# Altium schematic sheet Power.SchDoc(Power)
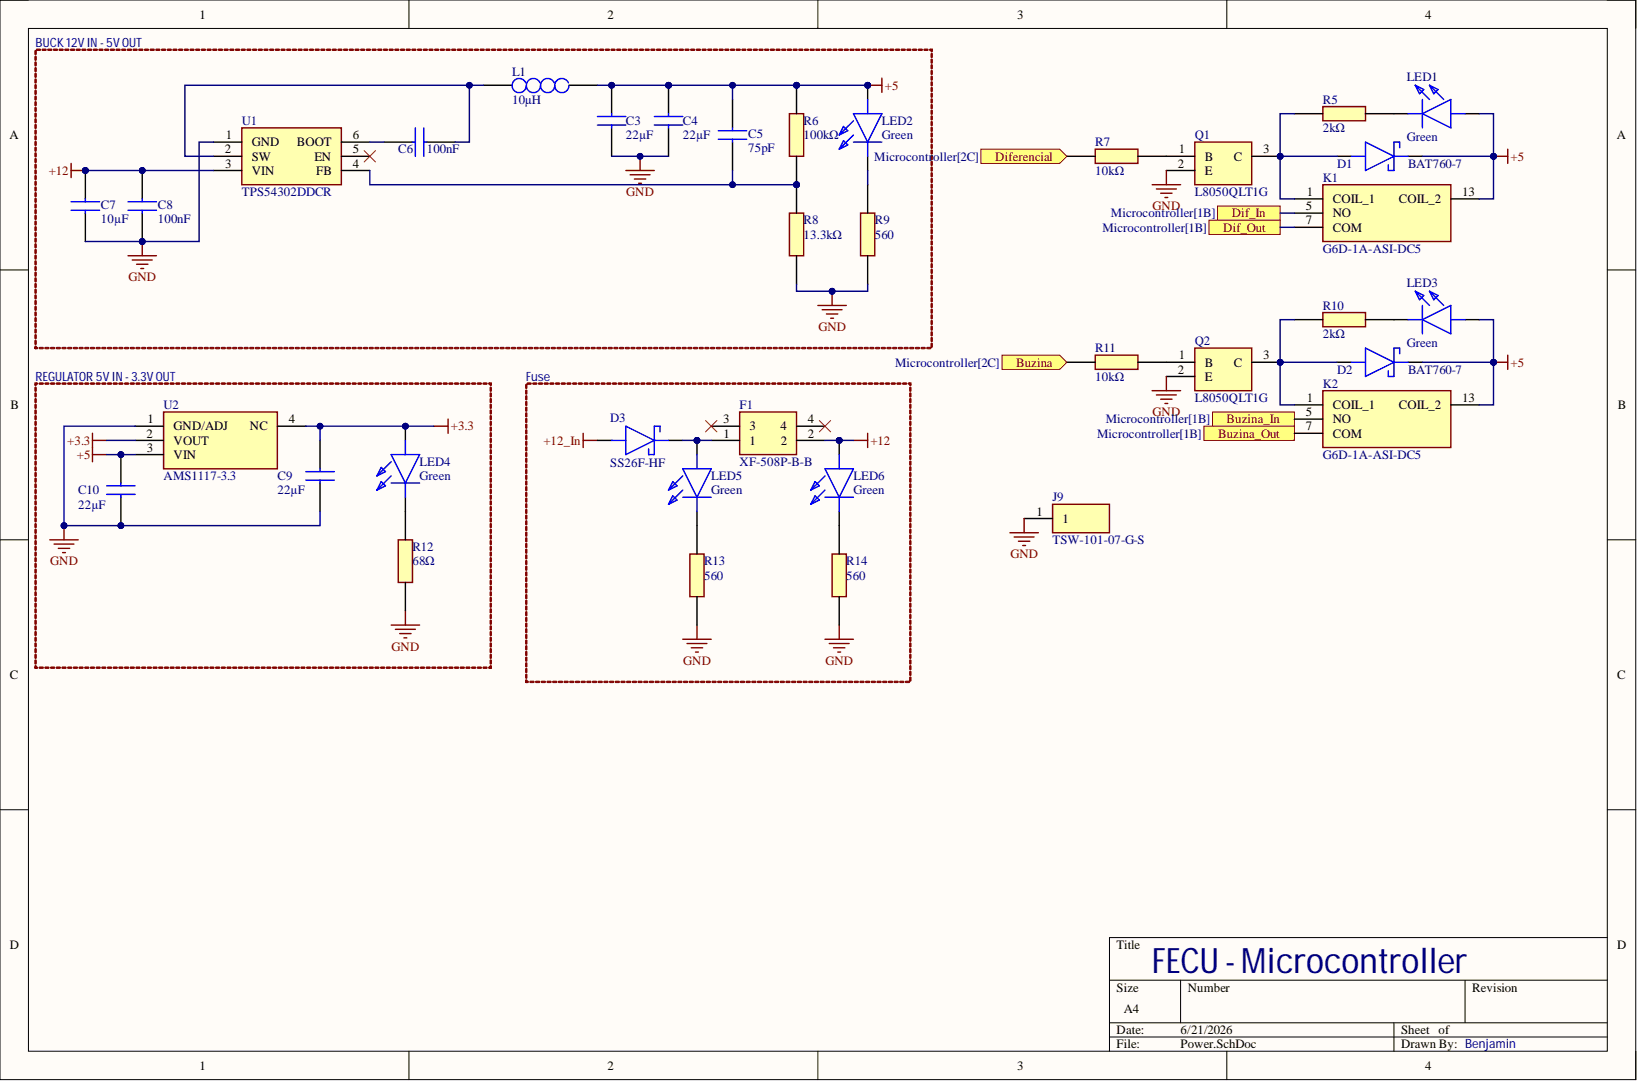
[diagram p0: Power.SchDoc]

## Parts
C3  22µF
C4  22µF
C5  75pF
C6  100nF
C7  10µF
C8  100nF
C9  22µF
C10  22µF
D1  BAT760-7
D2  BAT760-7
D3  SS26F-HF
F1  XF-508P-B-B
J9  TSW-101-07-G-S
K1  G6D-1A-ASI-DC5
K2  G6D-1A-ASI-DC5
L1  10µH
LED1  Green
LED2  Green
LED3  Green
LED4  Green
LED5  Green
LED6  Green
Q1  L8050QLT1G
Q2  L8050QLT1G
R5  2kΩ
R6  100kΩ
R7  10kΩ
R8  13.3kΩ
R9  560
R10  2kΩ
R11  10kΩ
R12  68Ω
R13  560
R14  560
U1  TPS54302DDCR
U2  AMS1117-3.3

## Ports
Buzina, Buzina_In, Buzina_Out, Dif_In, Dif_Out, Diferencial

## Nets
+3.3: C9.2 LED4.2 U2.2(VOUT) U2.4(NC)
+5: C3.2 C4.2 C5.2 C10.2 D1.1 D2.1 K1.13(COIL_2) K2.13(COIL_2) L1.2 LED1.2 LED2.2 LED3.2 R6.2 U2.3(VIN)
+12: C7.2 C8.2 F1.2 LED6.2 U1.3(VIN)
+12_In: D3.2
GND: C3.1 C4.1 C7.1 C8.1 C9.1 C10.1 J9.1 Q1.2 Q2.2 R8.1 R9.1 R12.1 R13.1 R14.1 U1.1(GND) U2.1(GND/ADJ)
Buzina: R11.2 ; port Buzina
Buzina_In: K2.5(NO) ; port Buzina_In
Buzina_Out: K2.7(COM) ; port Buzina_Out
Dif_In: K1.5(NO) ; port Dif_In
Dif_Out: K1.7(COM) ; port Dif_Out
Diferencial: R7.2 ; port Diferencial
NetC5_1: C5.1 R6.1 R8.2 U1.4(FB)
NetC6_1: C6.1 L1.1 U1.2(SW)
NetC6_2: C6.2 U1.6(BOOT)
NetD1_2: D1.2 K1.1(COIL_1) Q1.3 R5.1
NetD2_2: D2.2 K2.1(COIL_1) Q2.3 R10.1
NetD3_1: D3.1 F1.1 LED5.2
NetLED1_1: LED1.1 R5.2
NetLED2_1: LED2.1 R9.2
NetLED3_1: LED3.1 R10.2
NetLED4_1: LED4.1 R12.2
NetLED5_1: LED5.1 R13.2
NetLED6_1: LED6.1 R14.2
NetQ1_1: Q1.1 R7.1
NetQ2_1: Q2.1 R11.1

Not connected: F1 3 4; U1 5(EN)

## Library data of the parts
C3 C4 C9 C10 = CL21A226MAYNNNE: Capacitor; Manufacturer_Name: SAMSUNG; Arrow Part Number: CL21A226MAYNNNE; Arrow Price/Stock: https://www.arrow.com/en/products/cl21a226maynnne/samsung-electro-mechanics?utm_currency=USD&region=europe; Component Kind: Standard; Datasheet Link: http://product.samsungsem.com/mlcc/CL21A226MAYNNN.do; Height: 1.45mm; Manufacturer_Part_Number: CL21A226MAYNNNE; Mouser Part Number: 187-CL21A226MAYNNNE; Mouser Price/Stock: https://www.mouser.co.uk/ProductDetail/Samsung-Electro-Mechanics/CL21A226MAYNNNE?qs=xZ%2FP%252Ba9zWqY%2FVvCfXjMhqg%3D%3D
C5 = GQM2195C2E750JB12D: Capacitor; Manufacturer_Name: Murata Electronics; Component Kind: Standard; Datasheet Link: https://search.murata.co.jp/Ceramy/image/img/A01X/G101/ENG/GQM2195C2E750JB12-01A.pdf; Height: 1mm; Manufacturer_Part_Number: GQM2195C2E750JB12D
C6 C8 = CL21B104KBCNNNC: Capacitor; Manufacturer_Name: SAMSUNG; Arrow Part Number: CL21B104KBCNNNC; Arrow Price/Stock: https://www.arrow.com/en/products/cl21b104kbcnnnc/samsung-electro-mechanics?region=europe; Component Kind: Standard; Datasheet Link: https://datasheet.datasheetarchive.com/originals/distributors/Datasheets_SAMA/86a3eaf0882763ef32124d5901f9b833.pdf; Height: 0.95mm; Manufacturer_Part_Number: CL21B104KBCNNNC; Mouser Part Number: 187-CL21B104KBCNNNC; Mouser Price/Stock: https://www.mouser.co.uk/ProductDetail/Samsung-Electro-Mechanics/CL21B104KBCNNNC?qs=349EhDEZ59pEfpnm5yBEmQ%3D%3D
C7 = CL21A106KAYNNNE: Capacitor; Manufacturer_Name: SAMSUNG; Arrow Part Number: CL21A106KAYNNNE; Arrow Price/Stock: https://www.arrow.com/en/products/cl21a106kaynnne/samsung-electro-mechanics?utm_currency=USD&region=nac; Component Kind: Standard; Datasheet Link: https://datasheet.datasheetarchive.com/originals/distributors/Datasheets_SAMA/6a45835c9b7400e9b49c55c38a8ff4a2.pdf; Height: 1.45mm; Manufacturer_Part_Number: CL21A106KAYNNNE; Mouser Part Number: 187-CL21A106KAYNNNE; Mouser Price/Stock: https://www.mouser.co.uk/ProductDetail/Samsung-Electro-Mechanics/CL21A106KAYNNNE?qs=yOVawPpwOwmTXAd4dJtNjA%3D%3D
D1 D2 = BAT760-7: Schottky Diode; Manufacturer_Name: Diodes Incorporated; Arrow Part Number: BAT760-7; Arrow Price/Stock: https://www.arrow.com/en/products/bat760-7/diodes-incorporated?utm_currency=USD&region=nac; Component Kind: Standard; Datasheet Link: https://www.diodes.com//assets/Datasheets/BAT760.pdf; Height: 1.2mm; Manufacturer_Part_Number: BAT760-7; Mouser Part Number: 621-BAT760-7; Mouser Price/Stock: https://www.mouser.co.uk/ProductDetail/Diodes-Incorporated/BAT760-7?qs=JV7lzlMm3yKDDyO09xoRbQ%3D%3D
D3 = SS26F-HF: Schottky Diode; Manufacturer_Name: Comchip Technology; Component Kind: Standard; Datasheet Link: https://www.mouser.in/datasheet/2/80/20190806173210-1729198.pdf; Height: 1.2mm; Manufacturer_Part_Number: SS26F-HF
F1 = XF-508P-B-B: Hardware; Manufacturer_Name: XFCN; Component Kind: Standard; Datasheet Link: https://www.xfconn.com/uploads/soft/20240323/4-24032311150D91.pdf; Height: 7.37mm; Manufacturer_Part_Number: XF-508P-B-B
J9 = TSW-101-07-G-S: Connector; Manufacturer_Name: SAMTEC; Arrow Part Number: TSW-101-07-G-S; Arrow Price/Stock: https://www.arrow.com/en/products/tsw-101-07-g-s/samtec?region=europe; Component Kind: Standard; Datasheet Link: http://suddendocs.samtec.com/prints/tsw-xxx-xx-xxx-x-xx-xxx-mkt.pdf; Manufacturer_Part_Number: TSW-101-07-G-S; Mouser Part Number: 200-TSW10107GS; Mouser Price/Stock: https://www.mouser.co.uk/ProductDetail/Samtec/TSW-101-07-G-S?qs=hzq9O0YpnZscfPe5oPvABA%3D%3D
K1 K2 = G6D-1A-ASI-DC5: Relay or Contactor; Manufacturer_Name: Omron Electronics; Component Kind: Standard; Datasheet Link: http://www.mouser.com/ds/2/307/g6d-asi_0911-17274.pdf; Manufacturer_Part_Number: G6D-1A-ASI-DC5; Mouser Part Number: 653-G6D1A-ASI-DC5; Mouser Price/Stock: https://www.mouser.co.uk/ProductDetail/Omron-Electronics/G6D-1A-ASI-DC5?qs=SXIVkn%252Bm38nZtMCzK6jmZg%3D%3D
L1 = LQM21FN100M80L: Inductor; Manufacturer_Name: Murata Electronics; Arrow Part Number: LQM21FN100M80L; Arrow Price/Stock: https://www.arrow.com/en/products/lqm21fn100m80l/murata-manufacturing?region=europe; Component Kind: Standard; Datasheet Link: https://search.murata.co.jp/Ceramy/image/img/P02/JELF243B-0017.pdf; Height: 1.45mm; Manufacturer_Part_Number: LQM21FN100M80L; Mouser Part Number: 81-LQM21FN100M80L; Mouser Price/Stock: https://www.mouser.co.uk/ProductDetail/Murata-Electronics/LQM21FN100M80L?qs=%2F%252BYoplLUB5jHVlRNWlo0OQ%3D%3D
LED1-LED6 = LTST-C170KGKT: LED; Manufacturer_Name: Lite-On; Arrow Part Number: LTST-C170KGKT; Arrow Price/Stock: https://www.arrow.com/en/products/ltst-c170kgkt/lite-on-technology?utm_currency=USD&region=europe; Component Kind: Standard; Datasheet Link: http://uk.rs-online.com/web/p/products/6920907/?grossPrice=Y&cm_mmc=UK-PLA-DS3A-_-google-_-PLA_UK_EN_Displays_And_Optoelectronics-_-LEDs_And_LED_Accessories-_-PRODUCT+GROUP&matchtype=&gclid=Cj0KCQjw8vnMBRDgARIsACm_BhL5snkjsAk6gK-vmanqdq02kRfWctzcy3t-1Yoin; Height: 1.1mm; Manufacturer_Part_Number: LTST-C170KGKT; Mouser Part Number: 859-LTST-C170KGKT; Mouser Price/Stock: https://www.mouser.co.uk/ProductDetail/Lite-On/LTST-C170KGKT?qs=o1ACzGp%252Bek3F8d3sLmVFGg%3D%3D
Q1 Q2 = L8050QLT1G: Integrated Circuit; Manufacturer_Name: LRC; Component Kind: Standard; Datasheet Link: https://datasheet.datasheetarchive.com/originals/distributors/Datasheets-28/DSA-558356.pdf; Height: 1.11mm; Manufacturer_Part_Number: L8050QLT1G
R5 R10 = 0805W8F2001T5E: Resistor; Manufacturer_Name: UNI-ROYAL(Uniroyal Elec); Component Kind: Standard; Datasheet Link: https://datasheet.lcsc.com/lcsc/2206010200_UNI-ROYAL-Uniroyal-Elec-0805W8F2001T5E_C17604.pdf; Height: 0.65mm; Manufacturer_Part_Number: 0805W8F2001T5E
R6 = RC0805FR-07100KL: Resistor; Manufacturer_Name: KEMET; Arrow Part Number: RC0805FR-07100KL; Arrow Price/Stock: https://www.arrow.com/en/products/rc0805fr-07100kl/yageo?region=nac; Component Kind: Standard; Datasheet Link: http://www.yageo.com/documents/recent/PYu-RC_Group_51_RoHS_L_10.pdf; Height: 0.6mm; Manufacturer_Part_Number: RC0805FR-07100KL; Mouser Part Number: 603-RC0805FR-07100KL; Mouser Price/Stock: https://www.mouser.co.uk/ProductDetail/YAGEO/RC0805FR-07100KL?qs=8Y8p%252BasKcI7rEWn6FcVdAA%3D%3D
R7 R11 = RC0805FR-0710KL: Resistor; Manufacturer_Name: YAGEO; Arrow Part Number: RC0805FR-0710KL; Arrow Price/Stock: https://www.arrow.com/en/products/rc0805fr-0710kl/yageo?utm_currency=USD&region=europe; Component Kind: Standard; Datasheet Link: https://www.yageo.com/upload/media/product/productsearch/datasheet/rchip/PYu-RC_Group_51_RoHS_L_11.pdf; Height: 0.6mm; Manufacturer_Part_Number: RC0805FR-0710KL; Mouser Part Number: 603-RC0805FR-0710KL; Mouser Price/Stock: https://www.mouser.co.uk/ProductDetail/YAGEO/RC0805FR-0710KL?qs=7bY8808IzHq6vrU3BeiiYw%3D%3D
R8 = CRCW080513K3FKEA: Resistor; Manufacturer_Name: Vishay; Arrow Part Number: CRCW080513K3FKEA; Arrow Price/Stock: https://www.arrow.com/en/products/crcw080513k3fkea/vishay?region=nac; Component Kind: Standard; Datasheet Link: http://www.vishay.com/docs/20035/dcrcwe3.pdf; Height: 0.5mm; Manufacturer_Part_Number: CRCW080513K3FKEA; Mouser Part Number: 71-CRCW0805-13.3K-E3; Mouser Price/Stock: https://www.mouser.co.uk/ProductDetail/Vishay-Dale/CRCW080513K3FKEA?qs=0y7Riw94L4iJnrouDVBgwQ%3D%3D
R9 R13 R14 = 0805W8F5600T5E: Resistor; Manufacturer_Name: UNI-ROYAL(Uniroyal Elec); Component Kind: Standard; Datasheet Link: https://datasheet.lcsc.com/szlcsc/Uniroyal-Elec-0805W8F5600T5E_C28636.pdf; Height: 0.65mm; Manufacturer_Part_Number: 0805W8F5600T5E
R12 = RC0805FR-0768RL: Resistor; Manufacturer_Name: KEMET; Component Kind: Standard; Datasheet Link: http://www.yageo.com/documents/recent/PYu-RC_Group_51_RoHS_L_10.pdf; Height: 0.6mm; Manufacturer_Part_Number: RC0805FR-0768RL; Mouser Part Number: 603-RC0805FR-0768RL; Mouser Price/Stock: https://www.mouser.com/Search/Refine.aspx?Keyword=603-RC0805FR-0768RL
U1 = TPS54302DDCR: Integrated Circuit; Manufacturer_Name: Texas Instruments; Arrow Part Number: TPS54302DDCR; Arrow Price/Stock: https://www.arrow.com/en/products/tps54302ddcr/texas-instruments?utm_currency=USD&region=nac; Component Kind: Standard; Datasheet Link: http://www.ti.com/general/docs/suppproductinfo.tsp?distId=10&gotoUrl=http%3A%2F%2Fwww.ti.com%2Flit%2Fgpn%2Ftps54302; Height: 1.1mm; Manufacturer_Part_Number: TPS54302DDCR; Mouser Part Number: 595-TPS54302DDCR; Mouser Price/Stock: https://www.mouser.co.uk/ProductDetail/Texas-Instruments/TPS54302DDCR?qs=zEmsApcVOkUXM7UP0GSO2g%3D%3D
U2 = AMS1117-3.3: Integrated Circuit; Manufacturer_Name: Advanced; Component Kind: Standard; Datasheet Link: https://datasheet.datasheetarchive.com/originals/distributors/Datasheets-28/DSA-550594.pdf; Height: 1.8mm; Manufacturer_Part_Number: AMS1117-3.3
